# Altium schematic sheet 电原理图.SchDoc
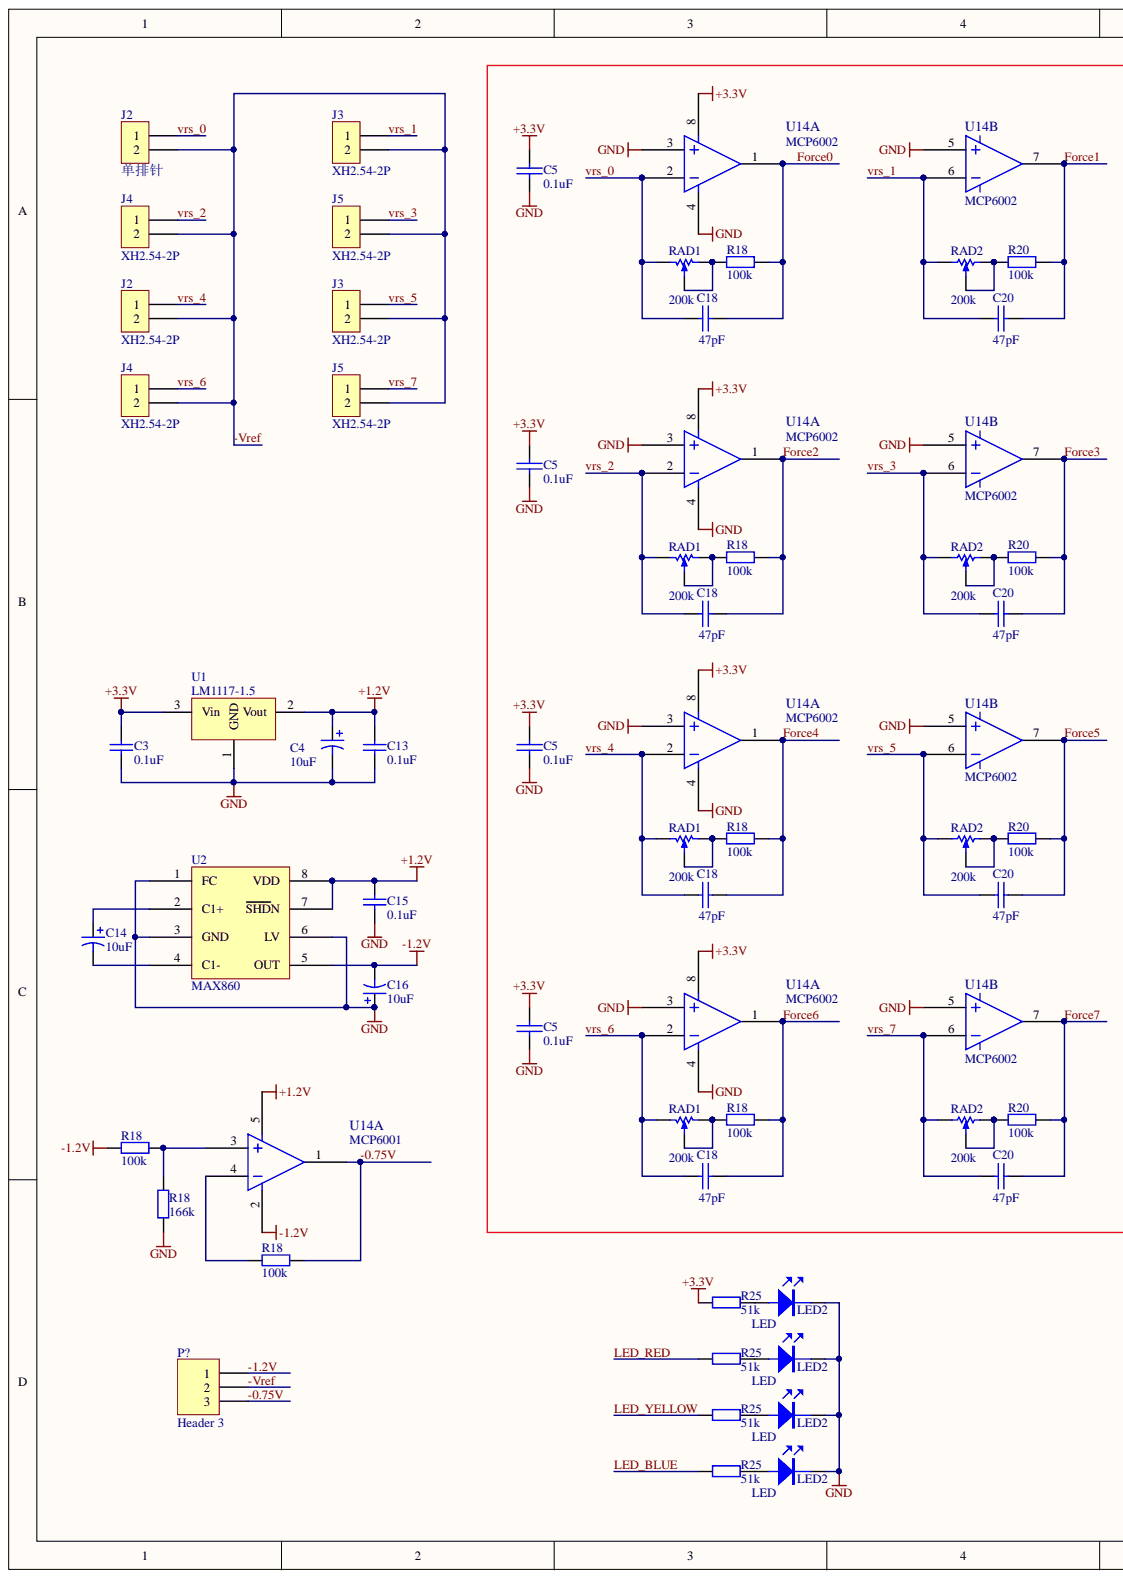
[diagram p0: 电原理图.SchDoc, left]
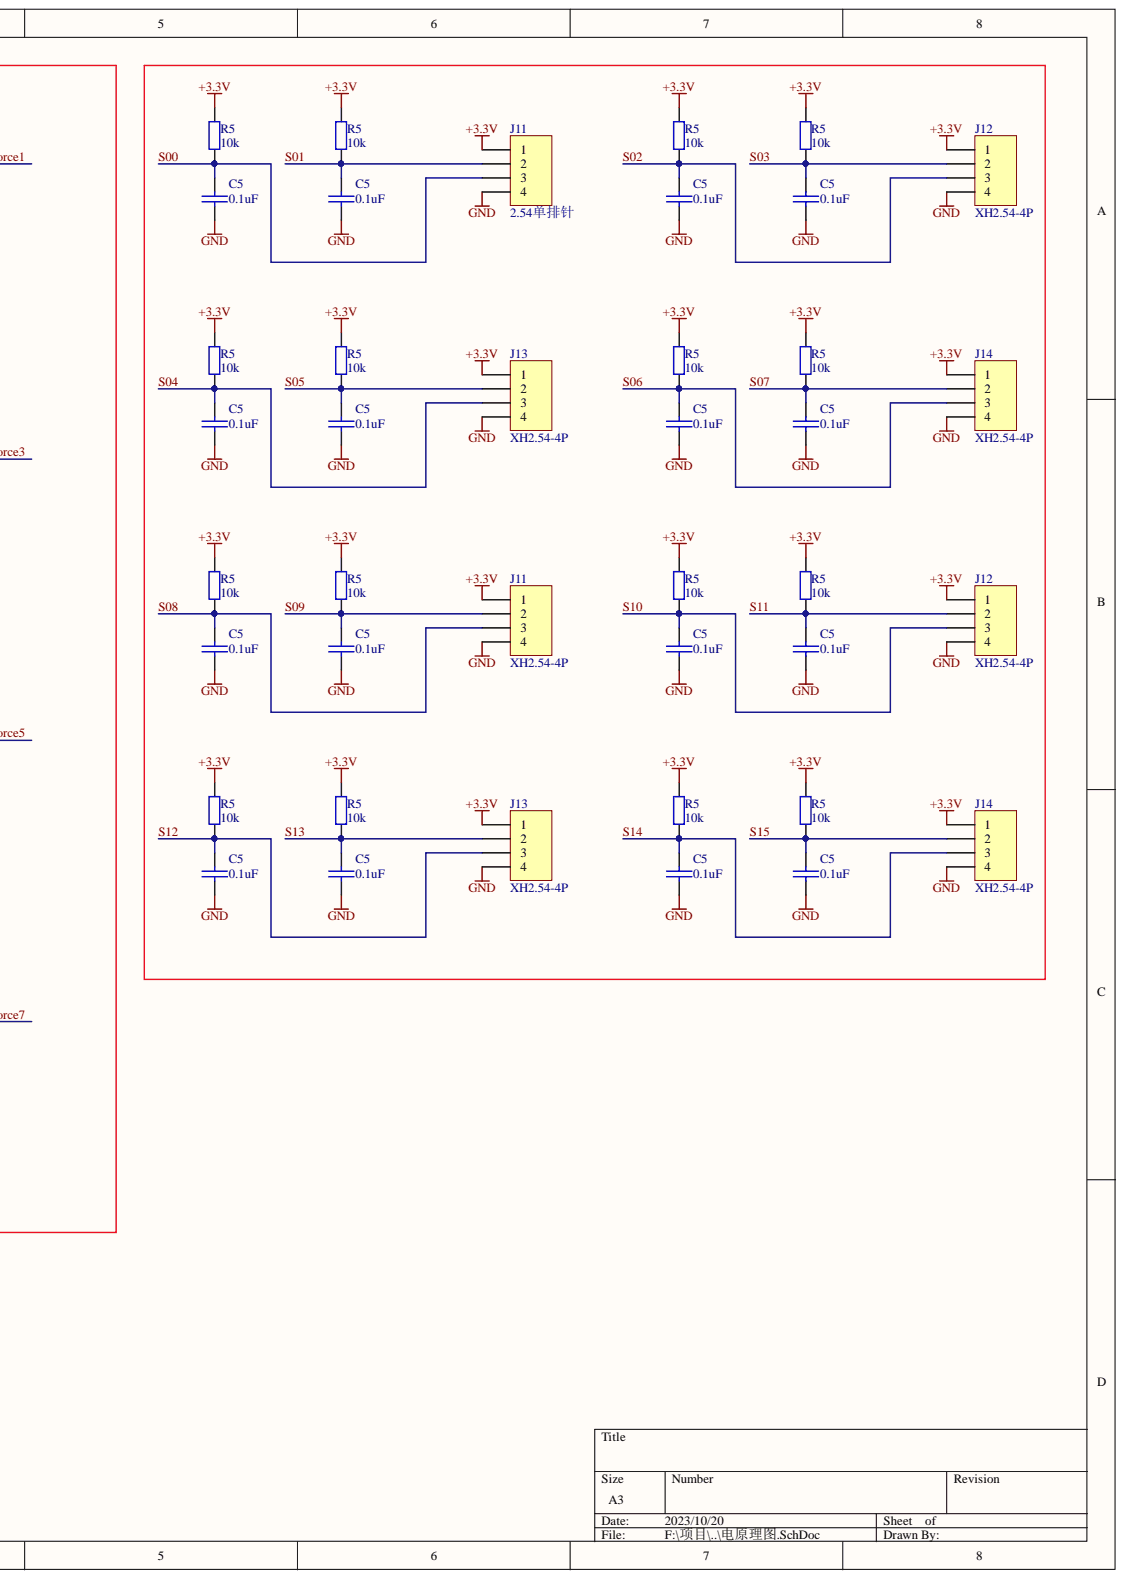
[diagram p1: 电原理图.SchDoc, right]

## Parts
C3  value 0.1uF  [CC0805]  (hidden comment: 0805-50V-0.1uF)
C4  value 10uF  [SMD-EC-F5]  (hidden comment: CD-50V-10uF-F5(SMD))
C5  value 0.1uF  [CC0805]  (hidden comment: 0805-50V-0.1uF)
C5  value 0.1uF  [CC0805]  (hidden comment: 0805-50V-0.1uF)
C5  value 0.1uF  [CC0805]  (hidden comment: 0805-50V-0.1uF)
C5  value 0.1uF  [CC0805]  (hidden comment: 0805-50V-0.1uF)
C5  value 0.1uF  [CC0805]  (hidden comment: 0805-50V-0.1uF)
C5  value 0.1uF  [CC0805]  (hidden comment: 0805-50V-0.1uF)
C5  value 0.1uF  [CC0805]  (hidden comment: 0805-50V-0.1uF)
C5  value 0.1uF  [CC0805]  (hidden comment: 0805-50V-0.1uF)
C5  value 0.1uF  [CC0805]  (hidden comment: 0805-50V-0.1uF)
C5  value 0.1uF  [CC0805]  (hidden comment: 0805-50V-0.1uF)
C5  value 0.1uF  [CC0805]  (hidden comment: 0805-50V-0.1uF)
C5  value 0.1uF  [CC0805]  (hidden comment: 0805-50V-0.1uF)
C5  value 0.1uF  [CC0805]  (hidden comment: 0805-50V-0.1uF)
C5  value 0.1uF  [CC0805]  (hidden comment: 0805-50V-0.1uF)
C5  value 0.1uF  [CC0805]  (hidden comment: 0805-50V-0.1uF)
C5  value 0.1uF  [CC0805]  (hidden comment: 0805-50V-0.1uF)
C5  value 0.1uF  [CC0805]  (hidden comment: 0805-50V-0.1uF)
C5  value 0.1uF  [CC0805]  (hidden comment: 0805-50V-0.1uF)
C5  value 0.1uF  [CC0805]  (hidden comment: 0805-50V-0.1uF)
C5  value 0.1uF  [CC0805]  (hidden comment: 0805-50V-0.1uF)
C13  value 0.1uF  [CC0805]  (hidden comment: 0805-50V-0.1uF)
C14  value 10uF  [SMD-EC-F5]  (hidden comment: CD-50V-10uF-F5(SMD))
C15  value 0.1uF  [CC0805]  (hidden comment: 0805-50V-0.1uF)
C16  value 10uF  [SMD-EC-F5]  (hidden comment: CD-50V-10uF-F5(SMD))
C18  value 47pF  [CC0805]  (hidden comment: 0805-50V-47pF)
C18  value 47pF  [CC0805]  (hidden comment: 0805-50V-47pF)
C18  value 47pF  [CC0805]  (hidden comment: 0805-50V-47pF)
C18  value 47pF  [CC0805]  (hidden comment: 0805-50V-47pF)
C20  value 47pF  [CC0805]  (hidden comment: 0805-50V-47pF)
C20  value 47pF  [CC0805]  (hidden comment: 0805-50V-47pF)
C20  value 47pF  [CC0805]  (hidden comment: 0805-50V-47pF)
C20  value 47pF  [CC0805]  (hidden comment: 0805-50V-47pF)
J2  XH2.54-2P  [XH2.54-2P]
J2  单排针  value XH2.54-2P  [XH2.54-2P]
J3  XH2.54-2P  [XH2.54-2P]
J3  XH2.54-2P  [XH2.54-2P]
J4  XH2.54-2P  [XH2.54-2P]
J4  XH2.54-2P  [XH2.54-2P]
J5  XH2.54-2P  [XH2.54-2P]
J5  XH2.54-2P  [XH2.54-2P]
J11  XH2.54-4P  [XH2.54-4P]
J11  2.54单排针  value XH2.54-4P  [XH2.54-4P]
J12  XH2.54-4P  [XH2.54-4P]
J12  XH2.54-4P  [XH2.54-4P]
J13  XH2.54-4P  [XH2.54-4P]
J13  XH2.54-4P  [XH2.54-4P]
J14  XH2.54-4P  [XH2.54-4P]
J14  XH2.54-4P  [XH2.54-4P]
LED2  value LED  [LED-R3]  (hidden comment: LED-φ3(翠绿))
LED2  value LED  [LED-R3]  (hidden comment: LED-φ3(翠绿))
LED2  value LED  [LED-R3]  (hidden comment: LED-φ3(翠绿))
LED2  value LED  [LED-R3]  (hidden comment: LED-φ3(翠绿))
P?  Header 3  [HDR1X3]
R5  value 10k  [0805]  (hidden comment: 0805-10kΩ±1％)
R5  value 10k  [0805]  (hidden comment: 0805-10kΩ±1％)
R5  value 10k  [0805]  (hidden comment: 0805-10kΩ±1％)
R5  value 10k  [0805]  (hidden comment: 0805-10kΩ±1％)
R5  value 10k  [0805]  (hidden comment: 0805-10kΩ±1％)
R5  value 10k  [0805]  (hidden comment: 0805-10kΩ±1％)
R5  value 10k  [0805]  (hidden comment: 0805-10kΩ±1％)
R5  value 10k  [0805]  (hidden comment: 0805-10kΩ±1％)
R5  value 10k  [0805]  (hidden comment: 0805-10kΩ±1％)
R5  value 10k  [0805]  (hidden comment: 0805-10kΩ±1％)
R5  value 10k  [0805]  (hidden comment: 0805-10kΩ±1％)
R5  value 10k  [0805]  (hidden comment: 0805-10kΩ±1％)
R5  value 10k  [0805]  (hidden comment: 0805-10kΩ±1％)
R5  value 10k  [0805]  (hidden comment: 0805-10kΩ±1％)
R5  value 10k  [0805]  (hidden comment: 0805-10kΩ±1％)
R5  value 10k  [0805]  (hidden comment: 0805-10kΩ±1％)
R18  value 166k  [0805]  (hidden comment: 0805-166kΩ±1％)
R18  value 100k  [0805]  (hidden comment: 0805-100kΩ±1％)
R18  value 100k  [0805]  (hidden comment: 0805-100kΩ±1％)
R18  value 100k  [0805]  (hidden comment: 0805-100kΩ±1％)
R18  value 100k  [0805]  (hidden comment: 0805-100kΩ±1％)
R18  value 100k  [0805]  (hidden comment: 0805-100kΩ±1％)
R18  value 100k  [0805]  (hidden comment: 0805-100kΩ±1％)
R20  value 100k  [0805]  (hidden comment: 0805-100kΩ±1％)
R20  value 100k  [0805]  (hidden comment: 0805-100kΩ±1％)
R20  value 100k  [0805]  (hidden comment: 0805-100kΩ±1％)
R20  value 100k  [0805]  (hidden comment: 0805-100kΩ±1％)
R25  value 51k  [0805]  (hidden comment: 0805-51kΩ±1％)
R25  value 51k  [0805]  (hidden comment: 0805-51kΩ±1％)
R25  value 51k  [0805]  (hidden comment: 0805-51kΩ±1％)
R25  value 51k  [0805]  (hidden comment: 0805-51kΩ±1％)
RAD1  value 200k  [3224W]  (hidden comment: 3224W-200k)
RAD1  value 200k  [3224W]  (hidden comment: 3224W-200k)
RAD1  value 200k  [3224W]  (hidden comment: 3224W-200k)
RAD1  value 200k  [3224W]  (hidden comment: 3224W-200k)
RAD2  value 200k  [3224W]  (hidden comment: 3224W-200k)
RAD2  value 200k  [3224W]  (hidden comment: 3224W-200k)
RAD2  value 200k  [3224W]  (hidden comment: 3224W-200k)
RAD2  value 200k  [3224W]  (hidden comment: 3224W-200k)
U1  LM1117-1.5  [SOT223]
U2  value MAX860  [SO-8]  (hidden comment: max660)
U14  MCP6001  [SO-8]  (parts A,B)
U14  MCP6002  [SO-8]  (part A)
U14  MCP6002  [SO-8]  (part B)
U14  MCP6002  [SO-8]  (part A)
U14  MCP6002  [SO-8]  (part B)
U14  MCP6002  [SO-8]  (part A)
U14  MCP6002  [SO-8]  (part B)
U14  MCP6002  [SO-8]  (part A)

## Nets
+1.2V: C4.1 C13.2 C15.2 U1.2 U2.7(SHDN) U2.8(VDD) U14.5
+3.3V: C3.2 C5.2 J11.1 J12.1 J13.1 J14.1 R5.1 R25.2 U1.3 U14.8
GND: C3.1 C4.2 C5.1 C5.2 C13.1 C15.1 C16.1 J11.4 J12.4 J13.4 J14.4 LED2.2 R18.1 U1.1 U2.1(FC) U2.3(GND) U2.6(LV) U14.3 U14.4 U14.5
-0.75V: P?.3 R18.2 U14.1
-1.2V: C16.2 P?.1 R18.2 U2.5(OUT) U14.2
-VREF: J2.2 J3.2 J4.2 J5.2 P?.2
FORCE0: C18.1 R18.2 U14.1
FORCE1: C20.1 R20.1 U14.7
FORCE2: C18.1 R18.2 U14.1
FORCE3: C20.1 R20.1 U14.7
FORCE4: C18.1 R18.2 U14.1
FORCE5: C20.1 R20.1 U14.7
FORCE6: C18.1 R18.2 U14.1
FORCE7: C20.1 R20.1 U14.7
LED_BLUE: R25.2
LED_RED: R25.2
LED_YELLOW: R25.2
S00: C5.1 J11.3 R5.2
S01: C5.1 J11.2 R5.2
S02: C5.1 J12.3 R5.2
S03: C5.1 J12.2 R5.2
S04: C5.1 J13.3 R5.2
S05: C5.1 J13.2 R5.2
S06: C5.1 J14.3 R5.2
S07: C5.1 J14.2 R5.2
S08: C5.1 J11.3 R5.2
S09: C5.1 J11.2 R5.2
S10: C5.1 J12.3 R5.2
S11: C5.1 J12.2 R5.2
S12: C5.1 J13.3 R5.2
S13: C5.1 J13.2 R5.2
S14: C5.1 J14.3 R5.2
S15: C5.1 J14.2 R5.2
VRS_0: C18.2 J2.1 RAD1.3 U14.2
VRS_1: C20.2 J3.1 RAD2.1 U14.6
VRS_2: C18.2 J4.1 RAD1.3 U14.2
VRS_3: C20.2 J5.1 RAD2.1 U14.6
VRS_4: C18.2 J2.1 RAD1.3 U14.2
VRS_5: C20.2 J3.1 RAD2.1 U14.6
VRS_6: C18.2 J4.1 RAD1.3 U14.2
VRS_7: C20.2 J5.1 RAD2.1 U14.6
NetC14_1: C14.1 U2.2(C1+)
NetC14_2: C14.2 U2.4(C1-)
NetLED2_1: LED2.1 R25.1
NetR18_1: R18.1 R18.2 RAD1.1 RAD1.2 U14.3 U14.4
NetR20_2: R20.2 RAD2.2 RAD2.3

## Library data of the parts
C3 C13 C15 = Cap: 贴片电容; Component Kind: Standard; Library Name: Miscellaneous Devices.IntLib
C4 C14 C16 = Cap Pol1: 贴片铝电解电容; Component Kind: Standard; Library Name: Miscellaneous Devices.IntLib
C5 C5 C5 C5 C5 C5 C5 C5 C5 C5 C5 C5 C5 C5 C5 C5 C5 C5 C5 C5 C18 C18 C18 C18 C20 C20 C20 C20 = CAP: 贴片电容; Component Kind: Standard
J2 J2 J3 J3 J4 J4 J5 J5 = CON2: 接插件; Component Kind: Standard
  J2 J3 J3 J4 J4 J5 J5: Value: XH2.54-2P
J11 J11 J12 J12 J13 J13 J14 J14 = Header 4: 插座; Component Kind: Standard; Library Name: Miscellaneous Connectors.IntLib; Signal Integrity: Connector
  J11 J12 J12 J13 J13 J14 J14: Value: XH2.54-4P
LED2 LED2 LED2 LED2 = LED: 发光二极管; Component Kind: Standard
P? = Header 3: Header, 3-Pin; Component Kind: Standard; LatestRevisionDate: 17-Jul-2002; LatestRevisionNote: Re-released for DXP Platform.; Library Name: Miscellaneous Connectors.IntLib; Signal Integrity: Connector; Publisher: Altium Limited
R5 R5 R5 R5 R5 R5 R5 R5 R5 R5 R5 R5 R5 R5 R5 R5 R18 R18 R18 R18 R18 R18 R18 R20 R20 R20 R20 = Res2: 贴片电阻; Component Kind: Standard; Library Name: Miscellaneous Devices.IntLib; Signal Integrity: Res; Simulation: RESISTOR
R25 R25 R25 R25 = Res2: 贴片电阻器; Component Kind: Standard; Library Name: Miscellaneous Devices.IntLib
RAD1 RAD1 RAD1 RAD1 RAD2 RAD2 RAD2 RAD2 = RPot SM: 贴片电位器; Component Kind: Standard; Library Name: Miscellaneous Devices.IntLib
U1 = 78: 三端稳压器; Component Kind: Standard; Value: LM1117-1.5
U2 = max860: 集成电路; Component Kind: Standard; Library Name: Schlib1.SchLib
U14 U14 U14 U14 U14 U14 U14 U14 = 1458: 集成电路; Component Kind: Standard
  U14: Value: MCP6001
  U14 U14 U14 U14 U14 U14 U14: Value: MCP6002
